# Altium schematic sheet SCH_BSPD_Sensorboard.SchDoc
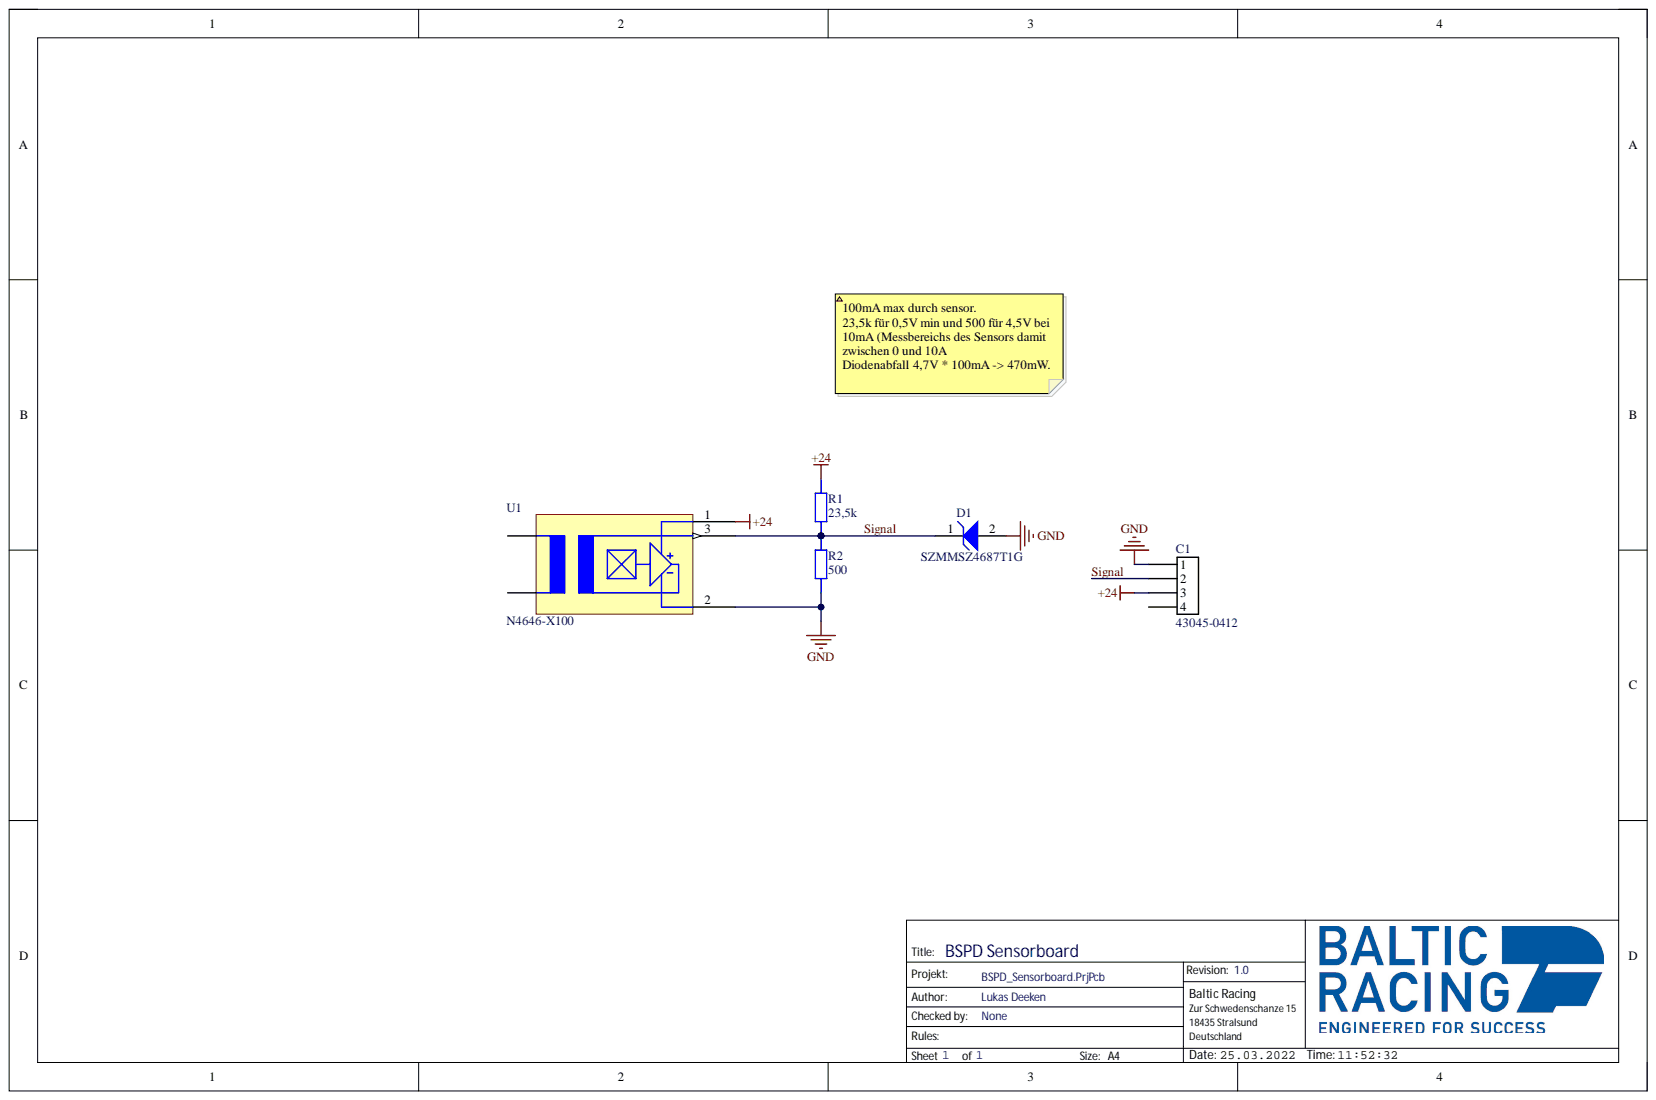
[diagram p0: SCH_BSPD_Sensorboard.SchDoc]

## Parts
C1  43045-0412  [43045-0412]
D1  SZMMSZ4687T1G  [ONSC-SOD-123-2-425-04_V]
R1  value 23,5k  [STACKPOLE CSR0603]  (hidden comment: SMD Resistor)
R2  value 500  [STACKPOLE CSR0603]  (hidden comment: SMD Resistor)
U1  N4646-X100  [N4646-X100]

## Nets
+24: C1.3 R1.2 U1.1
GND: C1.1 D1.2 R2.1 U1.2
Signal: C1.2 D1.1 R1.1 R2.2 U1.3

Not connected: C1 4

## Library data of the parts
C1 = MicroFit3.0 4-Pin: Molex Micro-Fit 3.0 4-Pin  600V  8.5A; Component Kind: Standard; Library Name: Standardbauteile.IntLib
D1 = CMP-1060-00576-1: Zener Voltage Regulator, 500 mW, 2-Pin SOD-123, Pb-Free, Tape and Reel; Manufacturer: On Semiconductor; P Max (W): 0.5; VZ Typ (V): 4.3; Component Kind: Standard; ComponentLink1Description: Manufacturer URL; ComponentLink1URL: http://www.onsemi.com/; ComponentLink2Description: Datasheet; ComponentLink2URL: http://www.onsemi.com/pub_link/Collateral/MMSZ4678T1-D.PDF; ComponentLink3Description: Package Specification; ComponentLink3URL: http://www.onsemi.com/pub_link/Collateral/425-04.PDF; DatasheetVersion: Rev. 9; Library Name: Altium Content Vault; Mounting Technology: Surface Mount; PackageDescription: 2-Pin SOD, Body 1.6 x 2.69 mm; PackageReference: SOD-123-2-425-04; PackageVersion: Rev. G, 10/2009; Packing: Tape and Reel; PartNumber: SZMMSZ4687T1G; RoHS: Pb-Free
R1 R2 = SMD Resistor: Resistor with multiple footprints; Power: 0.1W; Component Kind: Standard; Library Name: Standardbauteile.IntLib
U1 = N4646-X100: Component Kind: Standard; Library Name: Integrated_LibraryLukas_New.IntLib
